# Altium schematic sheet Audio.SchDoc(Audio)
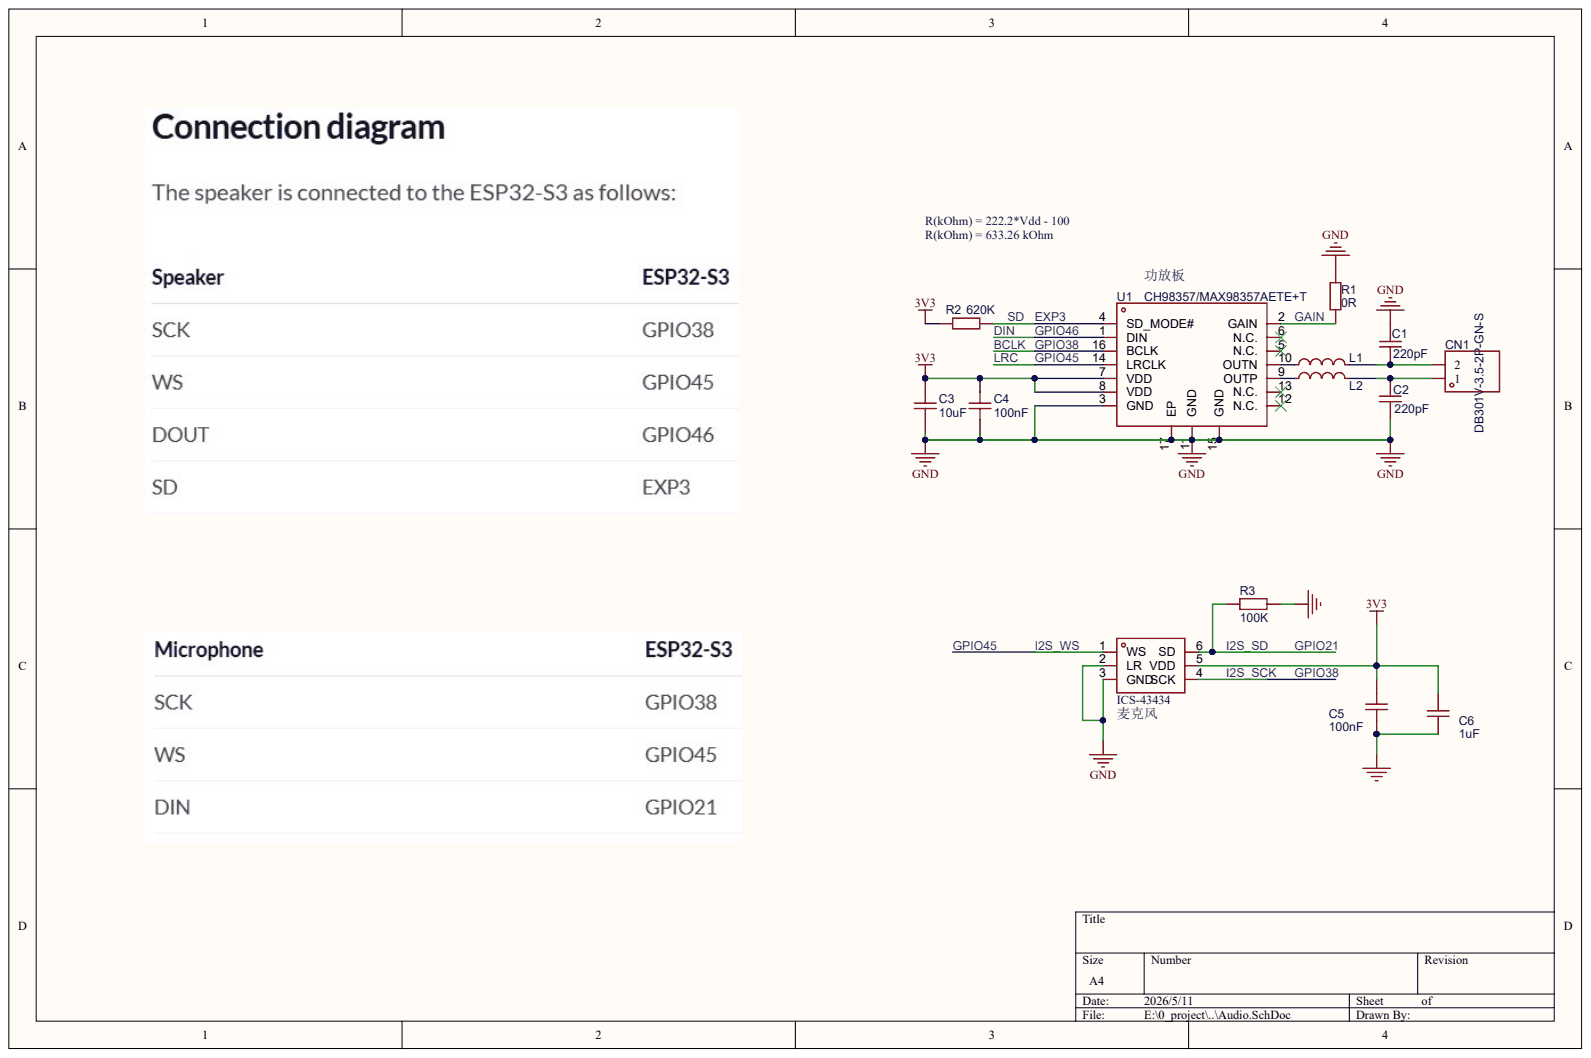
[diagram p0: Audio.SchDoc]

## Parts
C1  220pF
C2  220pF
C3  10uF
C4  100nF
C5  100nF
C6  1uF
CN1  DB301V-3.5-2P-GN-S
L1  BLM18KG601SN1D  (value not drawn)
L2  BLM18KG601SN1D  (value not drawn)
R1  0R
R2  620K
R3  100K
U1  CH98357/MAX98357AETE+T
U2  ICS-43434

## Nets
3V3: C3.2 C4.2 C5.2 C6.2 R2.1 U1.7(VDD) U1.8(VDD) U2.5(VDD)
GND: C1.2 C2.1 C3.1 C4.1 C5.1 C6.1 R1.1 R3.1 U1.3(GND) U1.11(GND) U1.15(GND) U1.17(EP) U2.2(LR) U2.3(GND)
BCLK: U1.16(BCLK) U2.4(SCK)
DIN: U1.1(DIN)
EXP3: R2.2 U1.4(SD_MODE#)
GAIN: R1.2 U1.2(GAIN)
GPIO21: R3.2 U2.6(SD)
GPIO45: U1.14(LRCLK) U2.1(WS)
NetC1_1: C1.1 CN1.2 L1.2
NetC2_2: C2.2 CN1.1 L2.2
NetL1_1: L1.1 U1.10(OUTN)
NetL2_1: L2.1 U1.9(OUTP)

Not connected: U1 5(N.C.) 6(N.C.) 12(N.C.) 13(N.C.)

## Library data of the parts
C1 = (no symbol name): DeviceName: CC0603KRX7R9BB221; Channel ID: $1e1110; AddInBom: yes; ModelId: ac125912035d415993ca9572d50418b1; ModelName: C0603_L1.6-W0.8-H0.8; ModelTransform: 62.992,31.496,0,0,0,0,0,0,0; Voltage Rated: 50V; Temperature Coefficient: X7R; SupplierPart: C107081; ManufacturerPart: CC0603KRX7R9BB221; Supplier Footprint: 0603; JLCPCB Part Class: Extended Part; Datasheet: https://atta.szlcsc.com/upload/public/pdf/source/20180910/C282072_33301C7F631E79921B82A8DAC6BCC5B3.pdf; Supplier: LCSC; ConvertToPcb: yes; FootprintName: C0603; Value: 220pF
C2 = (no symbol name): DeviceName: CC0603KRX7R9BB221; Channel ID: $1e1144; AddInBom: yes; ModelId: ac125912035d415993ca9572d50418b1; ModelName: C0603_L1.6-W0.8-H0.8; ModelTransform: 62.992,31.496,0,0,0,0,0,0,0; Voltage Rated: 50V; Temperature Coefficient: X7R; SupplierPart: C107081; ManufacturerPart: CC0603KRX7R9BB221; Supplier Footprint: 0603; JLCPCB Part Class: Extended Part; Datasheet: https://atta.szlcsc.com/upload/public/pdf/source/20180910/C282072_33301C7F631E79921B82A8DAC6BCC5B3.pdf; Supplier: LCSC; ConvertToPcb: yes; FootprintName: C0603; Value: 220pF
C3 = (no symbol name): Symbol: CGA0603X5R106K100JT; Device: CGA0805X5R106K250MT; AddInBom: yes; ModelId: 1ba041120af144c991958decab20d241; ModelName: C0805_L2.0-W1.3-H1.3; ModelTransform: 78.74,51.181,0,0,0,0,0,0.0005,0; Voltage Rating: 25V; Temperature Coefficient: X5R; SupplierPart: C6119887; ManufacturerPart: CGA0805X5R106K250MT; Supplier Footprint: 0805; JLCPCB Part Class: Extended Part; Supplier: LCSC; ConvertToPcb: yes; FootprintName: C0805; Value: 10uF
C4 = (no symbol name): Symbol: CGA0603X7R104K500JT; Device: CC0805KRX7R9BB104; AddInBom: yes; ModelId: 1ba041120af144c991958decab20d241; ModelName: C0805_L2.0-W1.3-H1.3; ModelTransform: 78.74,51.181,0,0,0,0,0,0.0005,0; Voltage Rated: 50V; Temperature Coefficient: X7R; SupplierPart: C49678; ManufacturerPart: CC0805KRX7R9BB104; Supplier Footprint: 0805; JLCPCB Part Class: Basic Part; Datasheet: https://atta.szlcsc.com/upload/public/pdf/source/20180224/C181747_15194369449651363981.pdf; Supplier: LCSC; ConvertToPcb: yes; FootprintName: C0805; Value: 100nF
C5 = (no symbol name): Origin Footprint: C0603; Device: CC0603KRX7R9BB104; Convert to PCB: yes; ModelId: ac125912035d415993ca9572d50418b1; ModelName: C0603_L1.6-W0.8-H0.8; ModelTransform: 62.992,31.496,0,0,0,0,0,0,0; Voltage Rated: 50V; Temperature Coefficient: X7R; Symbol: 100nf; Add into BOM: yes; SupplierPart: C14663; ManufacturerPart: CC0603KRX7R9BB104; Supplier Footprint: 0603; JLCPCB Part Class: Basic Part; Datasheet: https://atta.szlcsc.com/upload/public/pdf/source/20180910/C282072_33301C7F631E79921B82A8DAC6BCC5B3.pdf; Supplier: LCSC; FootprintName: C0603; Value: 100nF
C6 = (no symbol name): Symbol: CL10A105KB8NNNC; AddInBom: yes; ModelId: ac125912035d415993ca9572d50418b1; ModelName: C0603_L1.6-W0.8-H0.8; ModelTransform: 62.992,31.496,0,0,0,0,0,0,0; Voltage Rated: 50V; Temperature Coefficient: X5R; Device: CL10A105KB8NNNC; SupplierPart: C15849; ManufacturerPart: CL10A105KB8NNNC; Supplier Footprint: 0603; JLCPCB Part Class: Basic Part; Datasheet: https://atta.szlcsc.com/upload/public/pdf/source/20160103/1457707679084.pdf; Supplier: LCSC; ConvertToPcb: yes; FootprintName: C0603; Value: 1uF
CN1 = (no symbol name): Manufacturer: BOOMELE; Manufacturer Part: 1.25T-2AW; Symbol: BAT; Convert to PCB: yes; Origin Footprint: CONN-TH_2P-P1.25_1.25T-2AW; Device: BAT; Supplier: LCSC; Supplier Part: C145979; JLCPCB Part Class: Extended Part; Add into BOM: yes
L1 = (no symbol name): Number of Circuits: 1; Channel ID: $1e1075; AddInBom: yes; ModelId: 2bc5ec593d464d6ba9807aec0b5673c2; ModelName: L0603_L1.6-W0.8-H0.5_BEAD; ModelTransform: 62.992,31.496,0,180,0,0,0.094,0.629,0; Current Rating: 1.3A; JLCPCB Part Class: Extended Part; DeviceName: BLM18KG601SN1D; Circuits: 1; SupplierPart: C85833; ManufacturerPart: BLM18KG601SN1D; Supplier Footprint: 0603; Datasheet: https://atta.szlcsc.com/upload/public/pdf/source/20180329/C160981_FD3C2D2622A377E203B89F4AA32B0741.pdf; Supplier: LCSC; ConvertToPcb: yes; FootprintName: L0603
L2 = (no symbol name): Number of Circuits: 1; Channel ID: $1e1040; AddInBom: yes; ModelId: 2bc5ec593d464d6ba9807aec0b5673c2; ModelName: L0603_L1.6-W0.8-H0.5_BEAD; ModelTransform: 62.992,31.496,0,180,0,0,0.094,0.629,0; Current Rating: 1.3A; JLCPCB Part Class: Extended Part; DeviceName: BLM18KG601SN1D; Circuits: 1; SupplierPart: C85833; ManufacturerPart: BLM18KG601SN1D; Supplier Footprint: 0603; Datasheet: https://atta.szlcsc.com/upload/public/pdf/source/20180329/C160981_FD3C2D2622A377E203B89F4AA32B0741.pdf; Supplier: LCSC; ConvertToPcb: yes; FootprintName: L0603
R1 R3 = (no symbol name): Symbol: 0603WAF1003T5E; AddInBom: yes; ModelId: fc143cca1e79419f9cd78b61e9279f08; ModelName: R0603; ModelTransform: 31.8897,63.7794,0,90,0,0,0,0,0; Power(Watts): 100mW; Overload Voltage (Max): 75V; Device: 0603WAF1003T5E; SupplierPart: C25803; ManufacturerPart: 0603WAF1003T5E; Supplier Footprint: 0603; Datasheet: https://atta.szlcsc.com/upload/public/pdf/source/20200306/C422600_1E6D84923E4A46A82E41ADD87F860B5C.pdf; Supplier: LCSC; ConvertToPcb: yes; FootprintName: R0603
  R1: Value: 0R
  R3: Value: 100K
R2 = (no symbol name): Symbol: 0603WAF1004T5E; Device: 0805W8F1004T5E; AddInBom: yes; ModelId: 37a81e68749a4138bd2dfa6897b24b85; ModelName: R0805_L2.0-W1.3-H0.6; ModelTransform: 78.74,51.181,0,0,0,0,0,-0.0005,0; Power(Watts): 125mW; Overload Voltage (Max): 150V; SupplierPart: C17514; ManufacturerPart: 0805W8F1004T5E; Supplier Footprint: 0805; JLCPCB Part Class: Basic Part; Datasheet: https://atta.szlcsc.com/upload/public/pdf/source/20200306/C422600_1E6D84923E4A46A82E41ADD87F860B5C.pdf; Supplier: LCSC; ConvertToPcb: yes; FootprintName: R0805; Value: 620K
U1 = (no symbol name): AddInBom: yes; Device: CH98357/MAX98357AETE+T; Supplier: QQ:6089113; ConvertToPcb: yes; SymbolName: CH98357; FootprintName: CH98357
U2 = (no symbol name): Symbol: ICS-43434_C5656610
